# Altium schematic sheet cpu.SchDoc
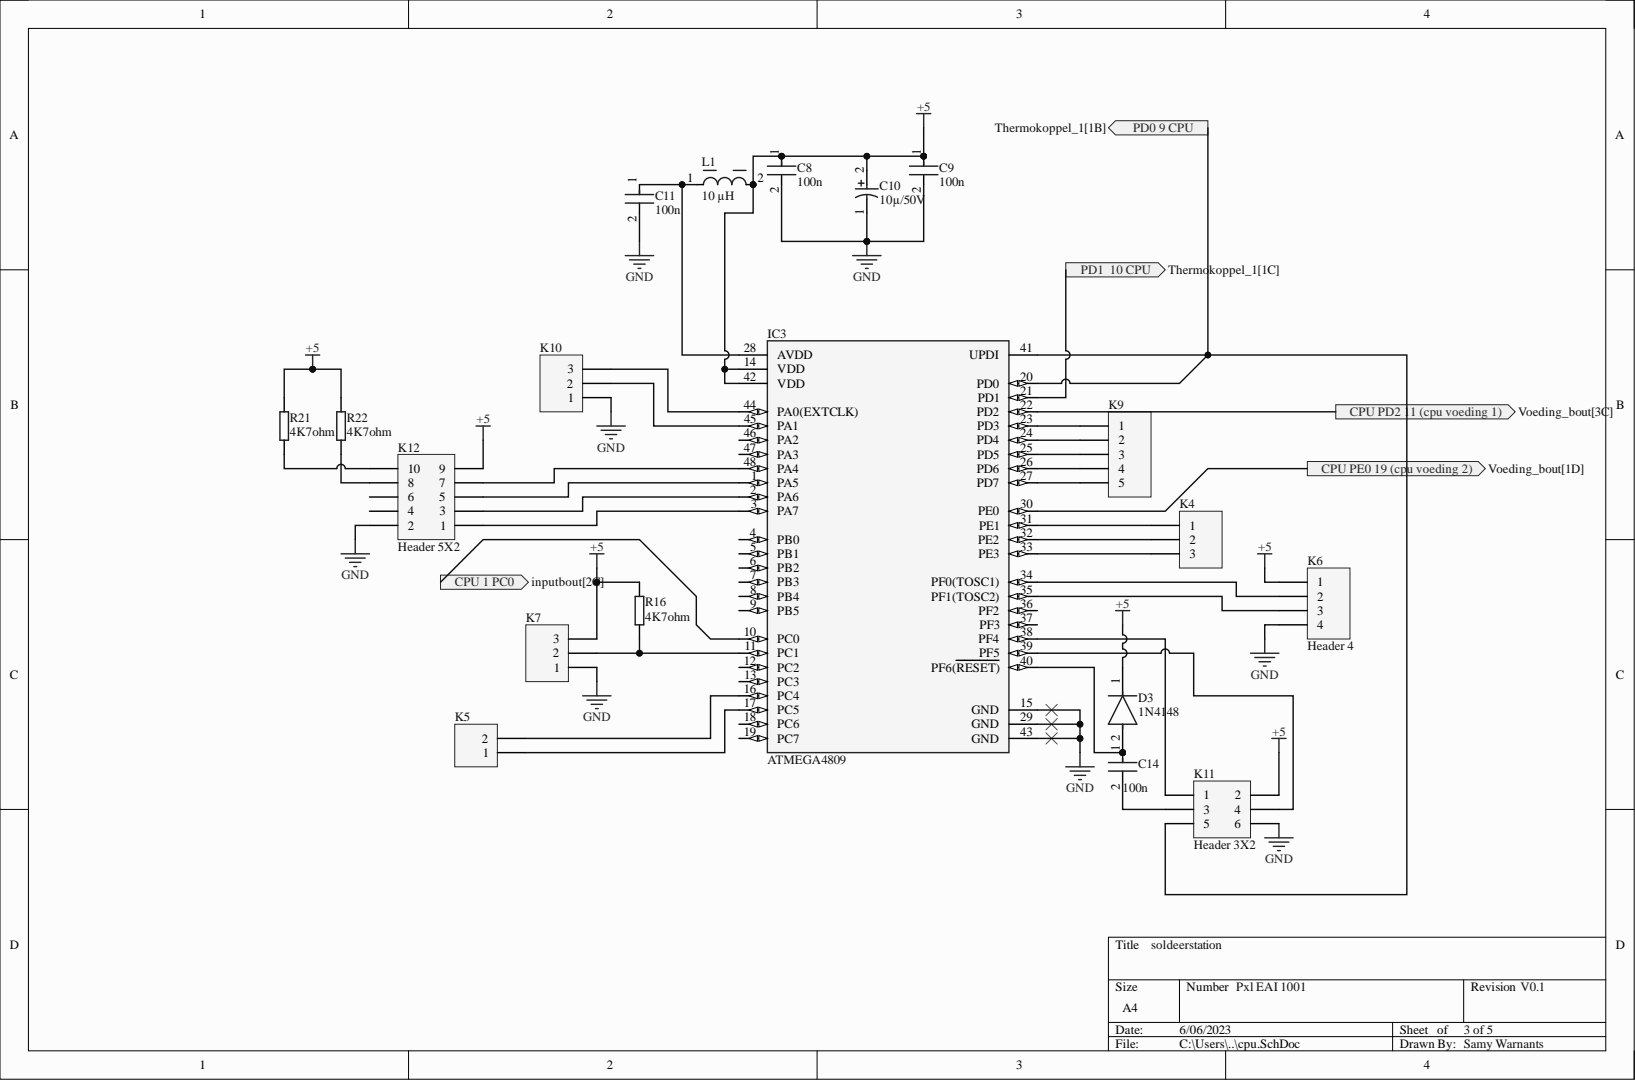
[diagram p0: cpu.SchDoc]

## Parts
C8  100n  [CC_0805_L]
C9  100n  [CC_0805_L]
C10  10µ/50V  [CE_D6,3_L4,5]
C11  100n  [CC_0805_L]
C14  100n  [CC_0805_L]
D3  1N4148  [SOD-123FL]
IC3  ATMEGA4809  [TQFP_48_M]
K4  Header 3  [HDR1X3]  (value not drawn)
K5  Header 2  [HDR1X2]  (value not drawn)
K6  Header 4  [HDR1X4]
K7  Header 3  [HDR1X3]  (value not drawn)
K9  Header 5  [HDR1X5]  (value not drawn)
K10  Header 3  [HDR1X3]  (value not drawn)
K11  Header 3X2  [HDR2X3]
K12  Header 5X2  [HDR2X5]
L1  10 µH  [CC_0805_L]
R16  4K7ohm  [R_0805_M]
R21  4K7ohm  [R_0805_M]
R22  4K7ohm  [R_0805_M]

## Ports
CPU 1 PC0, CPU PD2 11 (cpu voeding 1), CPU PE0 19 (cpu voeding 2), PD0 9 CPU, PD1  10 CPU

## Nets
+5: C8.1 C9.1 C10.2 D3.1 IC3.14(VDD) IC3.42(VDD) K6.1 K7.3 K11.2 K12.9 L1.2 R16.2 R21.2 R22.2
GND: C8.2 C9.2 C10.1 C11.2 IC3.15(GND) IC3.29(GND) IC3.43(GND) K6.4 K7.1 K10.1 K11.6 K12.2
CPU 1 PC0: IC3.10(PC0) ; port CPU 1 PC0
CPU PD2 11 (cpu voeding 1): IC3.22(PD2) ; port CPU PD2 11 (cpu voeding 1)
CPU PE0 19 (cpu voeding 2): IC3.30(PE0) ; port CPU PE0 19 (cpu voeding 2)
PD0 9 CPU: IC3.20(PD0) IC3.41(UPDI) K11.5 ; port PD0 9 CPU
PD1  10 CPU: IC3.21(PD1) ; port PD1  10 CPU
NetC11_1: C11.1 IC3.28(AVDD) L1.1
NetC14_1: C14.1 D3.2 IC3.40(PF6(RESET))
NetC14_2: C14.2 K11.3
NetIC3_1: IC3.1(PA5) K12.5
NetIC3_2: IC3.2(PA6) K12.3
NetIC3_3: IC3.3(PA7) K12.1
NetIC3_11: IC3.11(PC1) K7.2 R16.1
NetIC3_16: IC3.16(PC4) K5.2
NetIC3_17: IC3.17(PC5) K5.1
NetIC3_23: IC3.23(PD3) K9.1
NetIC3_24: IC3.24(PD4) K9.2
NetIC3_25: IC3.25(PD5) K9.3
NetIC3_26: IC3.26(PD6) K9.4
NetIC3_27: IC3.27(PD7) K9.5
NetIC3_31: IC3.31(PE1) K4.1
NetIC3_32: IC3.32(PE2) K4.2
NetIC3_33: IC3.33(PE3) K4.3
NetIC3_34: IC3.34(PF0(TOSC1)) K6.2
NetIC3_35: IC3.35(PF1(TOSC2)) K6.3
NetIC3_38: IC3.38(PF4) K11.1
NetIC3_39: IC3.39(PF5) K11.4
NetIC3_44: IC3.44(PA0(EXTCLK)) K10.3
NetIC3_45: IC3.45(PA1) K10.2
NetIC3_48: IC3.48(PA4) K12.7
NetK12_8: K12.8 R22.1
NetK12_10: K12.10 R21.1

Not connected: IC3 4(PB0) 5(PB1) 6(PB2) 7(PB3) 8(PB4) 9(PB5) 12(PC2) 13(PC3) 18(PC6) 19(PC7) 36(PF2) 37(PF3) 46(PA2) 47(PA3); K12 4 6

## Library data of the parts
C8 C9 C11 C14 = Capacitor_Ceramic: Manufacturer: KEMET; Manufacturer Part Number: C0805C224K5RACTU; Capacitance: 0.22 μF; Temperature Characteristic: X7R; Temperature Coefficient: 30ppm/°C; Tolerance: 0.1; Value: 0.22 μF; Voltage Rating: 50 V; Automotive: No; Category: Cap; Component Kind: Standard; ComponentLink2Description: Datasheet; ComponentLink2URL: https://content.kemet.com/datasheets/KEM_C1003_C0G_SMD.pdf; Device Class L1: Passive Components; Device Class L2: Capacitors; Device Class L3: Ceramic Capacitors; Digikey Description: CAP CER 0.22UF 50V X7R 0805; Height: 0.88mm; Ipc Land Pattern Name: CAPC200125X78; Lead Free: Yes; Material: Ceramic; Mouser Description: CAP CER 0.22UF 50V X7R 0805; Octopart: https://octopart.com/c0805c224k5ractu-kemet-144670; Package: C0805C-DN; RoHS: TRUE; Temperature: -55°C to +125°C; Temperature Range High: +125°C; Temperature Range Low: -55°C; Library Name: Soldeerstation_Final (1).SchLib
C10 = Capacitor_Electrolytic: Manufacturer: Panasonic; Voltage Rating: 25 V; Component Kind: Standard; ComponentLink1Description: Manufacturer URL; ComponentLink1URL: http://www.panasonic.com/; ComponentLink2Description: Datasheet; ComponentLink2URL: http://industrial.panasonic.com/cdbs/www-data/pdf/RDE0000/ABA0000C1181.pdf; DatasheetVersion: 03/2016; Height: 10.2 mm; Lead Pitch: 3.1 mm; Length: 10.2 mm; Max Operating Temperature: 105 degC; Min Operating Temperature: -55 degC; Mount: Surface Mount; Mounting Technology: Surface Mount; Package Quantity: 500; PackageDescription: 2-Pin Electrolytic Aluminum Capacitor, Body 8.3 x 8.3 mm; PackageReference: EEE-FK1E221P; Packaging: Tape and Reel; Pins: 2; REACH SVHC: No SVHC; RoHSCompliant: true; Library Name: Soldeerstation_Final (1).SchLib
D3 = Diode: Manufacturer: Diotec; Manufacturer Part Number: 1N4007; Capacitance: 15pF; Forward Current: 1A; Max Junction Temp: +175°C; Max Repetitive Reverse Voltage: 1000V; Max Surge Forward Current: 27A; Peak Reverse Current: 5uA; Value: 15pF; Automotive: No; Category: Diode; Component Kind: Standard; ComponentLink2Description: Datasheet; ComponentLink2URL: https://datasheet.octopart.com/1N4007-Diotec-datasheet-62346875.pdf; Device Class L1: Discrete Semiconductors; Device Class L2: Diodes; Device Class L3: Rectifier Diodes; Height: 2.8mm; Ipc Land Pattern Name: DIOAD888W100L520D280; Lead Free: Yes; Octopart: https://octopart.com/1n4007-diotec-4040411; Package: 1N4007; Reverse Voltage: 1000V; RoHS: TRUE; Temperature: -50°C to +175°C; Temperature Range High: +175°C; Temperature Range Low: -50°C; Library Name: Soldeerstation_Final (1).SchLib
IC3 = ATMEGA4809: Core Architecture: AVR; Interface: I2C; Memory Size: 48KB; Memory Type: FLASH; Number of I/Os: 41; Oscillator Type: Internal; Peripherals: Brown-out Detect/Reset; Case/Package: TQFP; Component Kind: Standard; Max Operating Temperature: 85°C; Min Operating Temperature: -40°C; Mount: Surface Mount; RoHS Compliant: Yes; Series: megaAVR® 0, Functional Safety (FuSa); Library Name: Soldeerstation_Final (1).SchLib
K4 K7 K10 = Header 3: Header, 3-Pin; Component Kind: Standard; LatestRevisionDate: 17-Jul-2002; LatestRevisionNote: Re-released for DXP Platform.; Library Name: Miscellaneous Connectors.IntLib; Signal Integrity: Connector; Publisher: Altium Limited
K5 = Header 2: Header, 2-Pin; Component Kind: Standard; LatestRevisionDate: 17-Jul-2002; LatestRevisionNote: Re-released for DXP Platform.; Library Name: Miscellaneous Connectors.IntLib; Signal Integrity: Connector; Publisher: Altium Limited
K6 = Header 4: Header, 4-Pin; Component Kind: Standard; LatestRevisionDate: 17-Jul-2002; LatestRevisionNote: Re-released for DXP Platform.; Library Name: Miscellaneous Connectors.IntLib; Signal Integrity: Connector; Publisher: Altium Limited
K9 = Header 5: Header, 5-Pin; Component Kind: Standard; LatestRevisionDate: 17-Jul-2002; LatestRevisionNote: Re-released for DXP Platform.; Library Name: Miscellaneous Connectors.IntLib; Signal Integrity: Connector; Publisher: Altium Limited
K11 = Header 3X2: Header, 3-Pin, Dual row; Component Kind: Standard; LatestRevisionDate: 17-Jul-2002; LatestRevisionNote: Re-released for DXP Platform.; Library Name: Miscellaneous Connectors.IntLib; Signal Integrity: Connector; Publisher: Altium Limited
K12 = Header 5X2: Header, 5-Pin, Dual row; Component Kind: Standard; LatestRevisionDate: 17-Jul-2002; LatestRevisionNote: Re-released for DXP Platform.; Library Name: Miscellaneous Connectors.IntLib; Signal Integrity: Connector; Publisher: Altium Limited
L1 = Choke: Current Rating: 8.5A; DC Resistance (DCR): 14.1mR; Inductance: 10uH; Inductance Tolerance: 20%; Saturation Current: 10A; Self Resonant Frequency: 18MHz; Series Resistance: 14.1R; Shielding: Shielded; Test Frequency: 100kHz; Case/Package: 1350; Component Kind: Standard; Height: 4.7mm; Height - Seated (Max): 0.197inch; Length: 0.512inch; Max DC Current: 8.5A; Max Operating Temperature: 155°C; Min Operating Temperature: -40°C; Mount: Surface Mount; Package Quantity: 1; Packaging: Tape and Reel; Ratings: AEC-Q200; Resistor Type: Wirewound; RMS Current (Irms): 8.5A; RoHS Compliant: Yes; Series: WE-HCI; Termination: SMD/SMT; Tolerance: 20%; Width: 12.8mm; Library Name: Soldeerstation_Final (1).SchLib
R16 R21 R22 = Resistor: Manufacturer: Vishay Dale; Part Number: CRCW08050000Z0EA; Component Kind: Standard; Library Name: Soldeerstation_Final (1).SchLib
